# Altium schematic sheet 05_Ethernet_Interface.SchDoc(05_Ethernet_Interface)
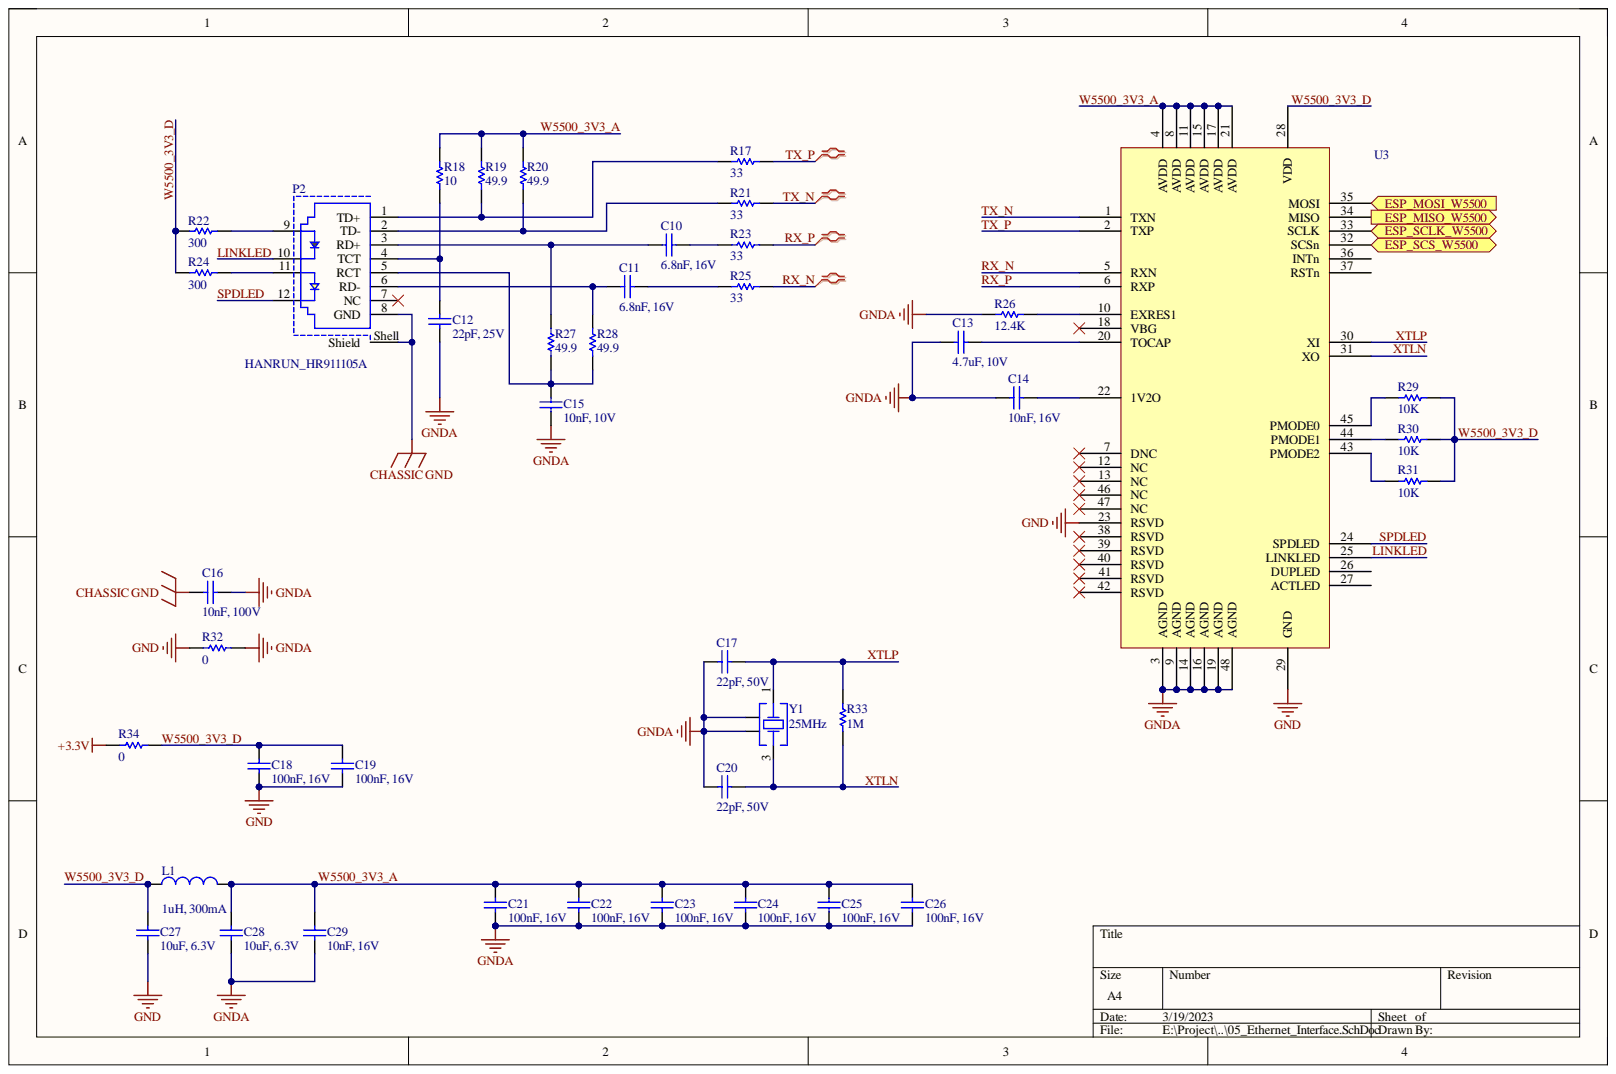
[diagram p0: 05_Ethernet_Interface.SchDoc]

## Parts
C10  6.8nF, 16V  [WCAP-CSGP_0603_H=0.8mm_Reflow]
C11  6.8nF, 16V  [WCAP-CSGP_0603_H=0.8mm_Reflow]
C12  22pF, 25V  [WCAP-CSGP_0603_H=0.8mm_Reflow]
C13  4.7uF, 10V  [WCAP-CSGP_0603_H=0.8mm_Reflow]
C14  10nF, 16V  [WCAP-CSGP_0603_H=0.8mm_Reflow]
C15  10nF, 10V  [WCAP-CSGP_0603_H=0.8mm_Reflow]
C16  10nF, 100V  [WCAP-CSGP_0603_H=0.8mm_Reflow]
C17  22pF, 50V  [WCAP-CSGP_0603_H=0.8mm_Reflow]
C18  100nF, 16V  [WCAP-CSGP_0603_H=0.8mm_Reflow]
C19  100nF, 16V  [WCAP-CSGP_0603_H=0.8mm_Reflow]
C20  22pF, 50V  [WCAP-CSGP_0603_H=0.8mm_Reflow]
C21  100nF, 16V  [WCAP-CSGP_0603_H=0.8mm_Reflow]
C22  100nF, 16V  [WCAP-CSGP_0603_H=0.8mm_Reflow]
C23  100nF, 16V  [WCAP-CSGP_0603_H=0.8mm_Reflow]
C24  100nF, 16V  [WCAP-CSGP_0603_H=0.8mm_Reflow]
C25  100nF, 16V  [WCAP-CSGP_0603_H=0.8mm_Reflow]
C26  100nF, 16V  [WCAP-CSGP_0603_H=0.8mm_Reflow]
C27  10uF, 6.3V  [WCAP-CSGP_0603_H=0.8mm_Reflow]
C28  10uF, 6.3V  [WCAP-CSGP_0603_H=0.8mm_Reflow]
C29  10nF, 16V  [WCAP-CSGP_0603_H=0.8mm_Reflow]
L1  value 1uH, 300mA  [I0603]  (hidden comment: Inductor)
P2  HANRUN_HR911105A  [HANRUN_HR911105A]
R17  value 33  [R0603]  (hidden comment: Resistor)
R18  value 10  [R0603]  (hidden comment: Resistor)
R19  value 49.9  [R0603]  (hidden comment: Resistor)
R20  value 49.9  [R0603]  (hidden comment: Resistor)
R21  value 33  [R0603]  (hidden comment: Resistor)
R22  value 300  [R0603]  (hidden comment: Resistor)
R23  value 33  [R0603]  (hidden comment: Resistor)
R24  value 300  [R0603]  (hidden comment: Resistor)
R25  value 33  [R0603]  (hidden comment: Resistor)
R26  value 12.4K  [R0603]  (hidden comment: Resistor)
R27  value 49.9  [R0603]  (hidden comment: Resistor)
R28  value 49.9  [R0603]  (hidden comment: Resistor)
R29  value 10K  [R0603]  (hidden comment: Resistor)
R30  value 10K  [R0603]  (hidden comment: Resistor)
R31  value 10K  [R0603]  (hidden comment: Resistor)
R32  value 0  [R0603]  (hidden comment: Resistor)
R33  value 1M  [R0603]  (hidden comment: Resistor)
R34  value 0  [R0805]  (hidden comment: Resistor)
U3  W5500  [TSQFP50P900X900X160-48N]
Y1  25MHz  [WE-XTAL_CFPX-180]

## Ports
ESP_MISO_W5500, ESP_MOSI_W5500, ESP_SCLK_W5500, ESP_~{SCS}_W5500

## Nets
+3.3V: R34.2
CHASSIC GND: C16.1 P2.8(GND) P2.Shell(Shield)
GND: C18.1 C19.1 C27.1 R32.2 U3.23(RSVD) U3.29(GND)
GNDA: C12.1 C13.1 C14.2 C15.1 C16.2 C17.1 C20.1 C21.1 C22.1 C23.1 C24.1 C25.1 C26.1 C28.1 C29.1 R26.2 R32.1 U3.3(AGND) U3.9(AGND) U3.14(AGND) U3.16(AGND) U3.19(AGND) U3.48(AGND) Y1.2 Y1.4
ESP_MISO_W5500: U3.34(MISO) ; port ESP_MISO_W5500
ESP_MOSI_W5500: U3.35(MOSI) ; port ESP_MOSI_W5500
ESP_~{SCS}_W5500: U3.32(SCSn) ; port ESP_~{SCS}_W5500
ESP_SCLK_W5500: U3.33(SCLK) ; port ESP_SCLK_W5500
LINKLED: P2.10 U3.25(LINKLED)
RX_N: R25.1 U3.5(RXN)
RX_P: R23.1 U3.6(RXP)
SPDLED: P2.12 U3.24(SPDLED)
TX_N: R21.1 U3.1(TXN)
TX_P: R17.1 U3.2(TXP)
W5500_3V3_A: C21.2 C22.2 C23.2 C24.2 C25.2 C26.2 C28.2 C29.2 L1.2 R18.2 R19.2 R20.2 U3.4(AVDD) U3.8(AVDD) U3.11(AVDD) U3.15(AVDD) U3.17(AVDD) U3.21(AVDD)
W5500_3V3_D: C18.2 C19.2 C27.2 L1.1 R22.2 R24.2 R29.2 R30.2 R31.2 R34.1 U3.28(VDD)
XTLN: C20.2 R33.1 U3.31(XO) Y1.3
XTLP: C17.2 R33.2 U3.30(XI) Y1.1
NetC10_1: C10.1 P2.3(RD+) R27.1
NetC10_2: C10.2 R23.2
NetC11_1: C11.1 P2.6(RD-) R28.1
NetC11_2: C11.2 R25.2
NetC12_2: C12.2 P2.4(TCT) R18.1
NetC13_2: C13.2 U3.20(TOCAP)
NetC14_1: C14.1 U3.22(1V2O)
NetC15_2: C15.2 P2.5(RCT) R27.2 R28.2
NetP2_1: P2.1(TD+) R17.2 R19.1
NetP2_2: P2.2(TD-) R20.1 R21.2
NetP2_9: P2.9 R22.1
NetP2_11: P2.11 R24.1
NetR26_1: R26.1 U3.10(EXRES1)
NetR29_1: R29.1 U3.45(PMODE0)
NetR30_1: R30.1 U3.44(PMODE1)
NetR31_1: R31.1 U3.43(PMODE2)

Not connected: P2 7(NC); U3 7(DNC) 12(NC) 13(NC) 18(VBG) 26(DUPLED) 27(ACTLED) 36(INTn) 37(RSTn) 38(RSVD) 39(RSVD) 40(RSVD) 41(RSVD) 42(RSVD) 46(NC) 47(NC)

## Library data of the parts
C10 C11 = 885012206039: WCAP-CSGP Multilayer Ceramic Chip Capacitor, General Purpose, size 0603, X7R, 6.8nF, 16V; Manufacturer: Wurth Elektronik; Capacitance Tolerance: +/-10%; Dissipation Factor: #NAME?; Isolation Resistance: >=10GOhm; Rated Voltage: 16VDC; Technical Reference: X7R0603682K016DFCT10000; Capacitance: 6.8nF; Ceramic Type: X7R; Component Kind: Standard; ComponentLink1Description: Manufacturer; ComponentLink1URL: http://www.we-online.de; ComponentLink2Description: Datasheet; ComponentLink2URL: https://www.we-online.com/catalog/datasheet/885012206039.pdf; Height: 0.8mm; Library Name: Altium_WCAP-CSGP (rev20a).IntLib; Manufacturer Part Number: 885012206039; Mounting Technology: SMT; Operating Temperature: -55 to +125degC; Packaging: Tape and Reel; Published Date: May 2020; Size: 0603
C12 = 885012006034: WCAP-CSGP Multilayer Ceramic Chip Capacitor, General Purpose, size 0603, NP0, 22pF, 25V; Manufacturer: Wurth Elektronik; Capacitance Tolerance: +/-5%; Dissipation Factor: Q>=400+20C; Isolation Resistance: >=10GOhm; Rated Voltage: 25VDC; Technical Reference: NP00603220J025DFCT10000; Capacitance: 22pF; Ceramic Type: NP0; Component Kind: Standard; ComponentLink1Description: Manufacturer; ComponentLink1URL: http://www.we-online.de; ComponentLink2Description: Datasheet; ComponentLink2URL: https://www.we-online.com/catalog/datasheet/885012006034.pdf; Height: 0.8mm; Library Name: Altium_WCAP-CSGP (rev20a).IntLib; Manufacturer Part Number: 885012006034; Mounting Technology: SMT; Operating Temperature: -55 to +125degC; Packaging: Tape and Reel; Published Date: May 2020; Size: 0603
C13 = 885012106012: WCAP-CSGP Multilayer Ceramic Chip Capacitor, General Purpose, size 0603, X5R, 4.7uF, 10V; Manufacturer: Wurth Elektronik; Capacitance Tolerance: +/-20%; Dissipation Factor: #NAME?; Isolation Resistance: >=0.02GOhm; Rated Voltage: 10VDC; Technical Reference: X5R0603475M010DFCT10000; Capacitance: 4.7uF; Ceramic Type: X5R; Component Kind: Standard; ComponentLink1Description: Manufacturer; ComponentLink1URL: http://www.we-online.de; ComponentLink2Description: Datasheet; ComponentLink2URL: https://www.we-online.com/catalog/datasheet/885012106012.pdf; Height: 0.8mm; Library Name: Altium_WCAP-CSGP (rev20a).IntLib; Manufacturer Part Number: 885012106012; Mounting Technology: SMT; Operating Temperature: -55 to +85degC; Packaging: Tape and Reel; Published Date: May 2020; Size: 0603
C14 C29 = 885012206040: WCAP-CSGP Multilayer Ceramic Chip Capacitor, General Purpose, size 0603, X7R, 10nF, 16V; Manufacturer: Wurth Elektronik; Capacitance Tolerance: +/-10%; Dissipation Factor: #NAME?; Isolation Resistance: >=10GOhm; Rated Voltage: 16VDC; Technical Reference: X7R0603103K016DFCT10000; Capacitance: 10nF; Ceramic Type: X7R; Component Kind: Standard; ComponentLink1Description: Manufacturer; ComponentLink1URL: http://www.we-online.de; ComponentLink2Description: Datasheet; ComponentLink2URL: https://www.we-online.com/catalog/datasheet/885012206040.pdf; Height: 0.8mm; Library Name: Altium_WCAP-CSGP (rev20a).IntLib; Manufacturer Part Number: 885012206040; Mounting Technology: SMT; Operating Temperature: -55 to +125degC; Packaging: Tape and Reel; Published Date: May 2020; Size: 0603
C15 = 885012206014: WCAP-CSGP Multilayer Ceramic Chip Capacitor, General Purpose, size 0603, X7R, 10nF, 10V; Manufacturer: Wurth Elektronik; Capacitance Tolerance: +/-10%; Dissipation Factor: #NAME?; Isolation Resistance: >=10GOhm; Rated Voltage: 10VDC; Technical Reference: X7R0603103K010DFCT10000; Capacitance: 10nF; Ceramic Type: X7R; Component Kind: Standard; ComponentLink1Description: Manufacturer; ComponentLink1URL: http://www.we-online.de; ComponentLink2Description: Datasheet; ComponentLink2URL: https://www.we-online.com/catalog/datasheet/885012206014.pdf; Height: 0.8mm; Library Name: Altium_WCAP-CSGP (rev20a).IntLib; Manufacturer Part Number: 885012206014; Mounting Technology: SMT; Operating Temperature: -55 to +125degC; Packaging: Tape and Reel; Published Date: May 2020; Size: 0603
C16 = 885012206114: WCAP-CSGP Multilayer Ceramic Chip Capacitor, General Purpose, size 0603, X7R, 10nF, 100V; Manufacturer: Wurth Elektronik; Capacitance Tolerance: +/-10%; Dissipation Factor: #NAME?; Isolation Resistance: >=10GOhm; Rated Voltage: 100VDC; Technical Reference: X7R0603103K100DFCT10000; Capacitance: 10nF; Ceramic Type: X7R; Component Kind: Standard; ComponentLink1Description: Manufacturer; ComponentLink1URL: http://www.we-online.de; ComponentLink2Description: Datasheet; ComponentLink2URL: https://www.we-online.com/catalog/datasheet/885012206114.pdf; Height: 0.8mm; Library Name: Altium_WCAP-CSGP (rev20a).IntLib; Manufacturer Part Number: 885012206114; Mounting Technology: SMT; Operating Temperature: -55 to +125degC; Packaging: Tape and Reel; Published Date: May 2020; Size: 0603
C17 C20 = 885012006053: WCAP-CSGP Multilayer Ceramic Chip Capacitor, General Purpose, size 0603, NP0, 22pF, 50V; Manufacturer: Wurth Elektronik; Capacitance Tolerance: +/-5%; Dissipation Factor: Q>=400+20C; Isolation Resistance: >=10GOhm; Rated Voltage: 50VDC; Technical Reference: NP00603220J050DFCT10000; Capacitance: 22pF; Ceramic Type: NP0; Component Kind: Standard; ComponentLink1Description: Manufacturer; ComponentLink1URL: http://www.we-online.de; ComponentLink2Description: Datasheet; ComponentLink2URL: https://www.we-online.com/catalog/datasheet/885012006053.pdf; Height: 0.8mm; Library Name: Altium_WCAP-CSGP (rev20a).IntLib; Manufacturer Part Number: 885012006053; Mounting Technology: SMT; Operating Temperature: -55 to +125degC; Packaging: Tape and Reel; Published Date: May 2020; Size: 0603
C18 C19 C21-C26 = 885012206046: WCAP-CSGP Multilayer Ceramic Chip Capacitor, General Purpose, size 0603, X7R, 100nF, 16V; Manufacturer: Wurth Elektronik; Capacitance Tolerance: +/-10%; Dissipation Factor: #NAME?; Isolation Resistance: >=5GOhm; Rated Voltage: 16VDC; Technical Reference: X7R0603104K016DFCT10000; Capacitance: 100nF; Ceramic Type: X7R; Component Kind: Standard; ComponentLink1Description: Manufacturer; ComponentLink1URL: http://www.we-online.de; ComponentLink2Description: Datasheet; ComponentLink2URL: https://www.we-online.com/catalog/datasheet/885012206046.pdf; Height: 0.8mm; Library Name: Altium_WCAP-CSGP (rev20a).IntLib; Manufacturer Part Number: 885012206046; Mounting Technology: SMT; Operating Temperature: -55 to +125degC; Packaging: Tape and Reel; Published Date: May 2020; Size: 0603
C27 C28 = 885012106006: WCAP-CSGP Multilayer Ceramic Chip Capacitor, General Purpose, size 0603, X5R, 10uF, 6.3V; Manufacturer: Wurth Elektronik; Capacitance Tolerance: +/-20%; Dissipation Factor: #NAME?; Isolation Resistance: >=0.01GOhm; Rated Voltage: 6.3VDC; Technical Reference: X5R0603106M6R3DFCT10000; Capacitance: 10uF; Ceramic Type: X5R; Component Kind: Standard; ComponentLink1Description: Manufacturer; ComponentLink1URL: http://www.we-online.de; ComponentLink2Description: Datasheet; ComponentLink2URL: https://www.we-online.com/catalog/datasheet/885012106006.pdf; Height: 0.8mm; Library Name: Altium_WCAP-CSGP (rev20a).IntLib; Manufacturer Part Number: 885012106006; Mounting Technology: SMT; Operating Temperature: -55 to +85degC; Packaging: Tape and Reel; Published Date: May 2020; Size: 0603
L1 = Inductor: Value: 1uH, 300mA; Component Kind: Standard; Library Name: MiclsLib.IntLib
Y1 = 830108340809: WE-XTAL Quartz Crystal, SMT, CFPX-180, 25MHz, +/-20ppm; Manufacturer: Wurth Elektronik; Frequency Stability: +/-30ppm; Load Capacitance: 12pF; Component Kind: Standard; ComponentLink1Description: Manufacturer; ComponentLink1URL: http://www.we-online.de; ComponentLink2Description: Datasheet; ComponentLink2URL: https://www.we-online.com/catalog/datasheet/830108340809.pdf; Frequency: 25MHz; Frequency Tolerance: +/-20ppm; Library Name: Altium_WE-XTAL (rev20c).IntLib; Manufacturer Part Number: 830108340809; Mounting Technology: SMT; Operating Temperature: -40 to +105degC; Packaging: Tape and Reel; Published Date: November 2020; Size: CFPX-180
P2 = HANRUN_HR911105A: Component Kind: Standard; Library Name: MiclsLib.IntLib
R17-R34 = Resistor: Component Kind: Standard; Library Name: MiclsLib.IntLib
U3 = W5500: Component Kind: Standard; Library Name: MiclsLib.IntLib
